# Altium schematic sheet PSU Breakout.SchDoc("PSU Breakout")
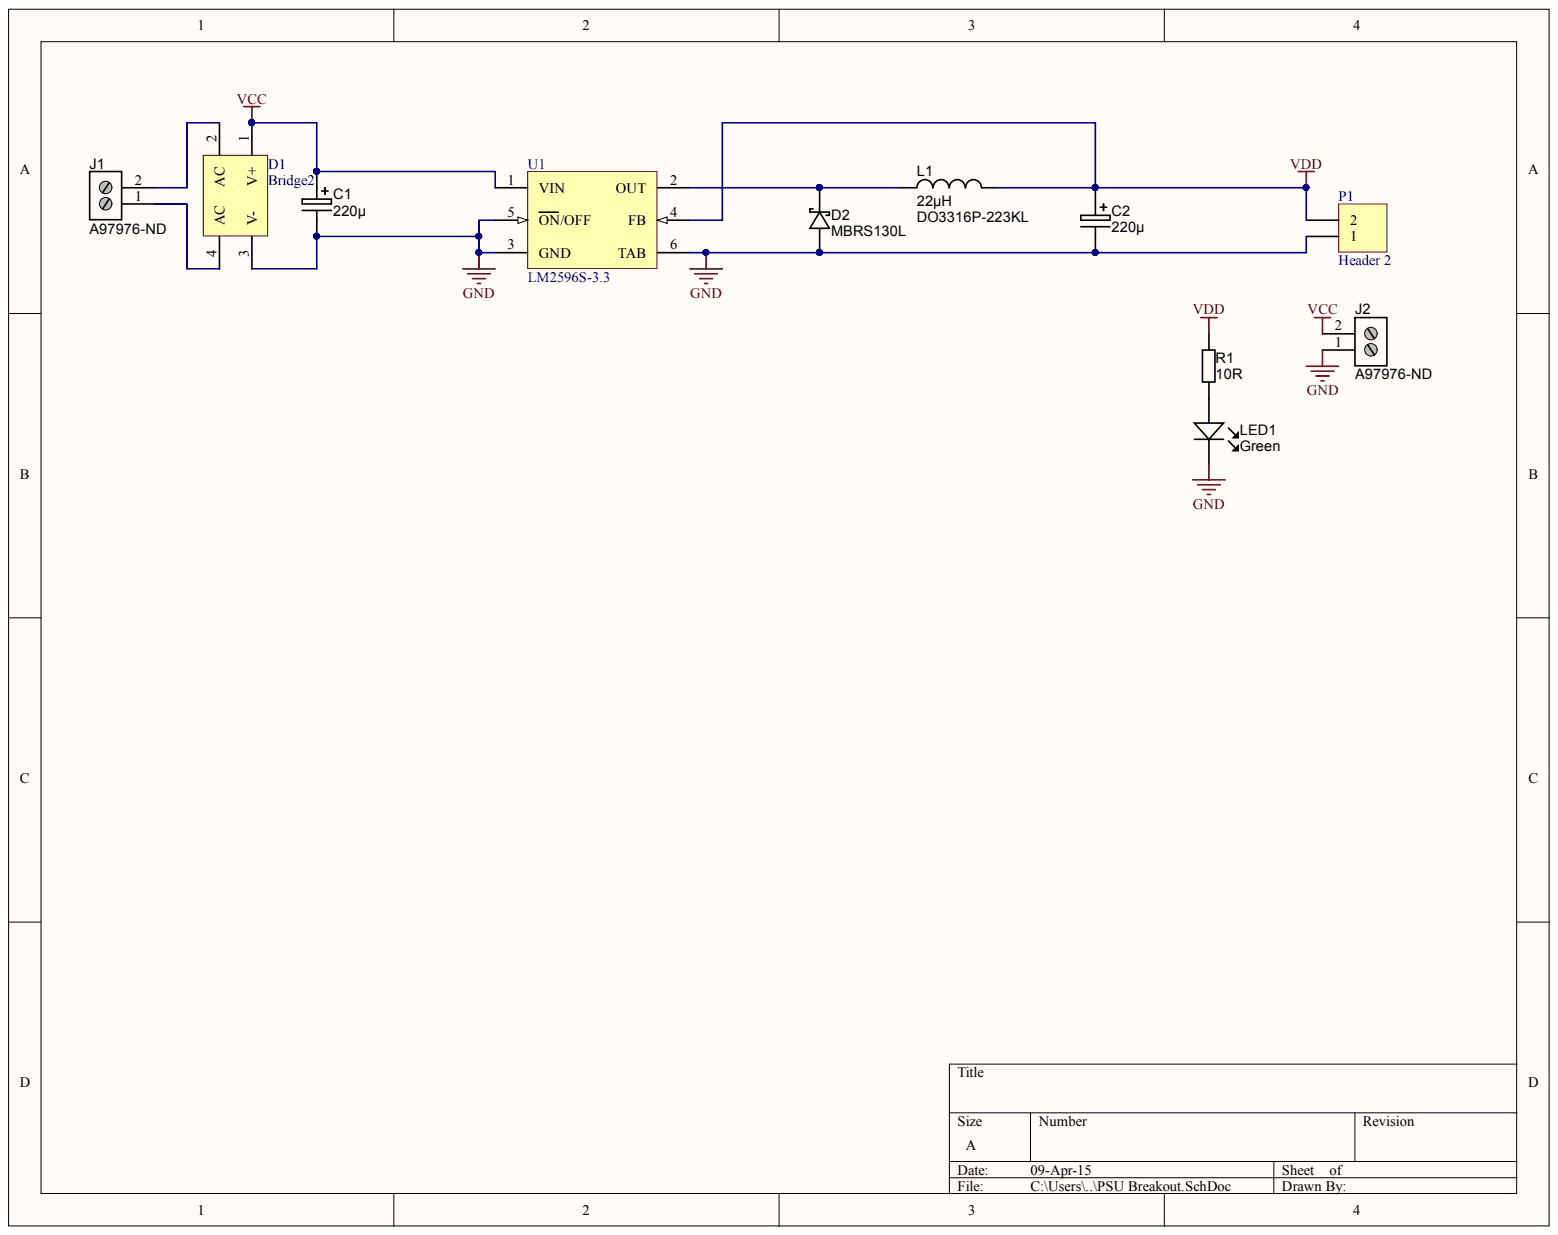
[diagram p0: PSU Breakout.SchDoc]

## Parts
C1  220µ  [CAP_ELEC_SIZE_G]
C2  220µ  [CAP_ELEC_SIZE_E]
D1  Bridge2  [KBL]
D2  MBRS130L  [DO-214AA]
J1  Supplier Part Number 1: A97976-ND  [2P_PCB_ScrewTerm_90S_3.81MM]  (hidden comment: Stackable ScrewTerm)
J2  Supplier Part Number 1: A97976-ND  [2P_PCB_ScrewTerm_90S_3.81MM]  (hidden comment: Stackable ScrewTerm)
L1  22µH  Manufacturer Part Number 1: DO3316P-223KL  [Inductor]
LED1  Green  [LED-0603-GREEN]
P1  Header 2  [HDR1X2]
R1  value 10R  [RESC1608X55N]  (hidden comment: Thick Film)
U1  LM2596S-3.3  [TS5B_N]

## Nets
GND: C1.2 C2.2 D1.3(V-) D2.A J2.1 LED1.K P1.1 U1.3(GND) U1.5(ON/OFF) U1.6(TAB)
VCC: C1.1 D1.1(V+) J2.2 U1.1(VIN)
VDD: C2.1 L1.2 P1.2 R1.1 U1.4(FB)
NetD1_2: D1.2(AC) J1.2
NetD1_4: D1.4(AC) J1.1
NetD2_K: D2.K L1.1 U1.2(OUT)
NetLED1_A: LED1.A R1.2

## Library data of the parts
C1 C2 = CAPE_220u_10V_SMD: Capacitor SMD Alum Elec 220uF 20% 10V; Manufacturer 1: Panasonic - ECG; Manufacturer Part Number 1: EEE-FK1A221P; Material: Al; Ratings: 10V; 105°C; SCDType: undef; Component Kind: Standard; Library Name: PBL - Capacitors.SCHLIB; Package / Case: Radial - Surface Mount; Supplier 1: Digi-Key; Supplier Part Number 1: PCE3775CT-ND; Tolerance: ±20%; Value: 220µ
D1 = Bridge2: Diode Bridge; Component Kind: Standard; LatestRevisionDate: 17-Jul-2002; LatestRevisionNote: Re-released for DXP Platform.; Library Name: Miscellaneous Devices.IntLib; PackageDescription: Bridge Rectifier; 4 Terminals; Body 16.8 x 16.8 mm (typ); Pitch 10.67 mm; PackageReference: D-46_6A; Published: 24-Mar-1999; Simulation: BRIDGE; Publisher: Altium Limited
D2 = DIODE_SCHOTTKY_MBRS130L: Diode Schottky SMD 30V 2A DO-214AA; Manufacturer 1: Fairchild Semiconductor; Material: Si; Ratings: 30Vr; 2A; SCDType: source; Component Kind: Standard; Library Name: PBL - Diodes.SCHLIB; Manufacturer Part Number 1: MBRS130L; Package / Case: DO-214AA, SMB; Supplier 1: Digi-Key; Supplier Part Number 1: MBRS130LFSCT-ND
J1 J2 = 2P_ScrewTerm_90S_3.81MM: Terminal Block Screw Type THT 2-Position 3.81mm pitch; Manufacturer 1: Tyco Electronics; Manufacturer Part Number 1: 1776113-2; Pitch: 0.150" (3.81mm); Positions Per Level: 2; SCDType: undef; Value: 2 position; Category: Connectors Interconnects; Color: Black; Component Kind: Standard; Library Name: PBL - Connectors.SCHLIB; Package / Case: Side Entry; 3.81mm pitch; Supplier 1: Digi-Key
L1 = INDC_22u_DO3316P-223: Inductor Power SMD 22µH; Manufacturer 1: Coilcraft; Ratings: 2.3 Adc; SCDType: spec; Tolerance: ±10%; Value: 22µ; Component Kind: Standard; Library Name: PBL - Inductors.SCHLIB; Package / Case: 12.95x9.4mm
LED1 = LED_SMD_Green_0603_570nm: LED 0603 SMD Green 570nm 50mcd 20mA; Manufacturer 1: Kingbright Company LLC; Manufacturer Part Number 1: APT1608CGCK; Lens Style/Size: Rectangle with Flat Top, 1.1mm x 0.8mm; Lens Type: Clear; Material: AlGaInP; Ratings: 20mcd min; 50 mcd typ; 570 nm; SCDType: source; Viewing Angle: 120°; Voltage - Forward (Vf) @ 10mA: 2.0V; Voltage - Forward (Vf) Typ: 2.1V; Wavelength - Peak: 574nm; Color: Green; Component Kind: Standard; ComponentLink1Description: http://www.us.kingbright.com/images/catalog/SPEC/APT1608CGCK.pdf; ComponentLink1URL: http://www.us.kingbright.com/images/catalog/SPEC/APT1608CGCK.pdf; Current - Test: 20mA; Library Name: PBL - Light Sources.SCHLIB; Package / Case: 0603 (1608 metric); RoHS 1: RoHS Compliant; Supplier 1: Digi-Key; Supplier Part Number 1: 754-1116-1-ND; Wavelength - Dominant: 570nm
P1 = Header 2: Header, 2-Pin; Component Kind: Standard; LatestRevisionDate: 17-Jul-2002; LatestRevisionNote: Re-released for DXP Platform.; Library Name: Miscellaneous Connectors.IntLib; Signal Integrity: Connector; Publisher: Altium Limited
R1 = RES_10R_0603_5%: Resistor SMD chip 10 Ohm 0.1W 5% 0603; Manufacturer 1: Yageo; Manufacturer Part Number 1: RC0603JR-0710RL; Ratings: 1/10 W; SCDType: spec; Component Kind: Standard; ComponentLink1Description: http://www.yageo.com/documents/recent/PYu-RC0603_51_RoHS_L_4.pdf; ComponentLink1URL: http://www.yageo.com/documents/recent/PYu-RC0603_51_RoHS_L_4.pdf; Library Name: PBL - Resistors.SCHLIB; Package / Case: 0603 (1608 metric); Supplier 1: Digi-Key; Supplier Part Number 1: 311-10GRCT-ND; Tolerance: ±5%
U1 = LM2596S-3.3: SIMPLE SWITCHER® Power Converter 150 KHz 3A Step-Down Voltage Regulator, 5-pin TO-263; Manufacturer: National Semiconductor; PackageTopMarking: NSUZXYTTE#*LM2596S*-3.3 P+; PowerWise: N; Code_JEDEC: MO-169-AB; Component Kind: Standard; ComponentLink1Description: LM2596 Datasheet; ComponentLink1URL: http://www.national.com/ds/LM/LM2596.pdf; ComponentLink2Description: LM2596 Web Page; ComponentLink2URL: http://www.national.com/pf/LM/LM2596.html; ComponentLink3Description: TS5B Package Page; ComponentLink3URL: http://www.national.com/packaging/folders/ts5b.html; CompType: Switcher; DatasheetVersion: May-2002; Library Name: NSC Switcher.IntLib; PackageDescription: TO-263, Molded, .400 x .390 x .180in, 5 Lead, .067in Pitch, Surface Mount; PackageReference: TS5B; PackageVersion: revD, Apr-2005; PartNumber: LM2596S-3.3; PinCount: 5; Published: 4-Dec-2008; RoHS: N; Supplier 1: Farnell; Supplier Part Number 1: 1214545; Publisher: Altium Limited
